# Altium schematic sheet DiPho_AFE.SchDoc
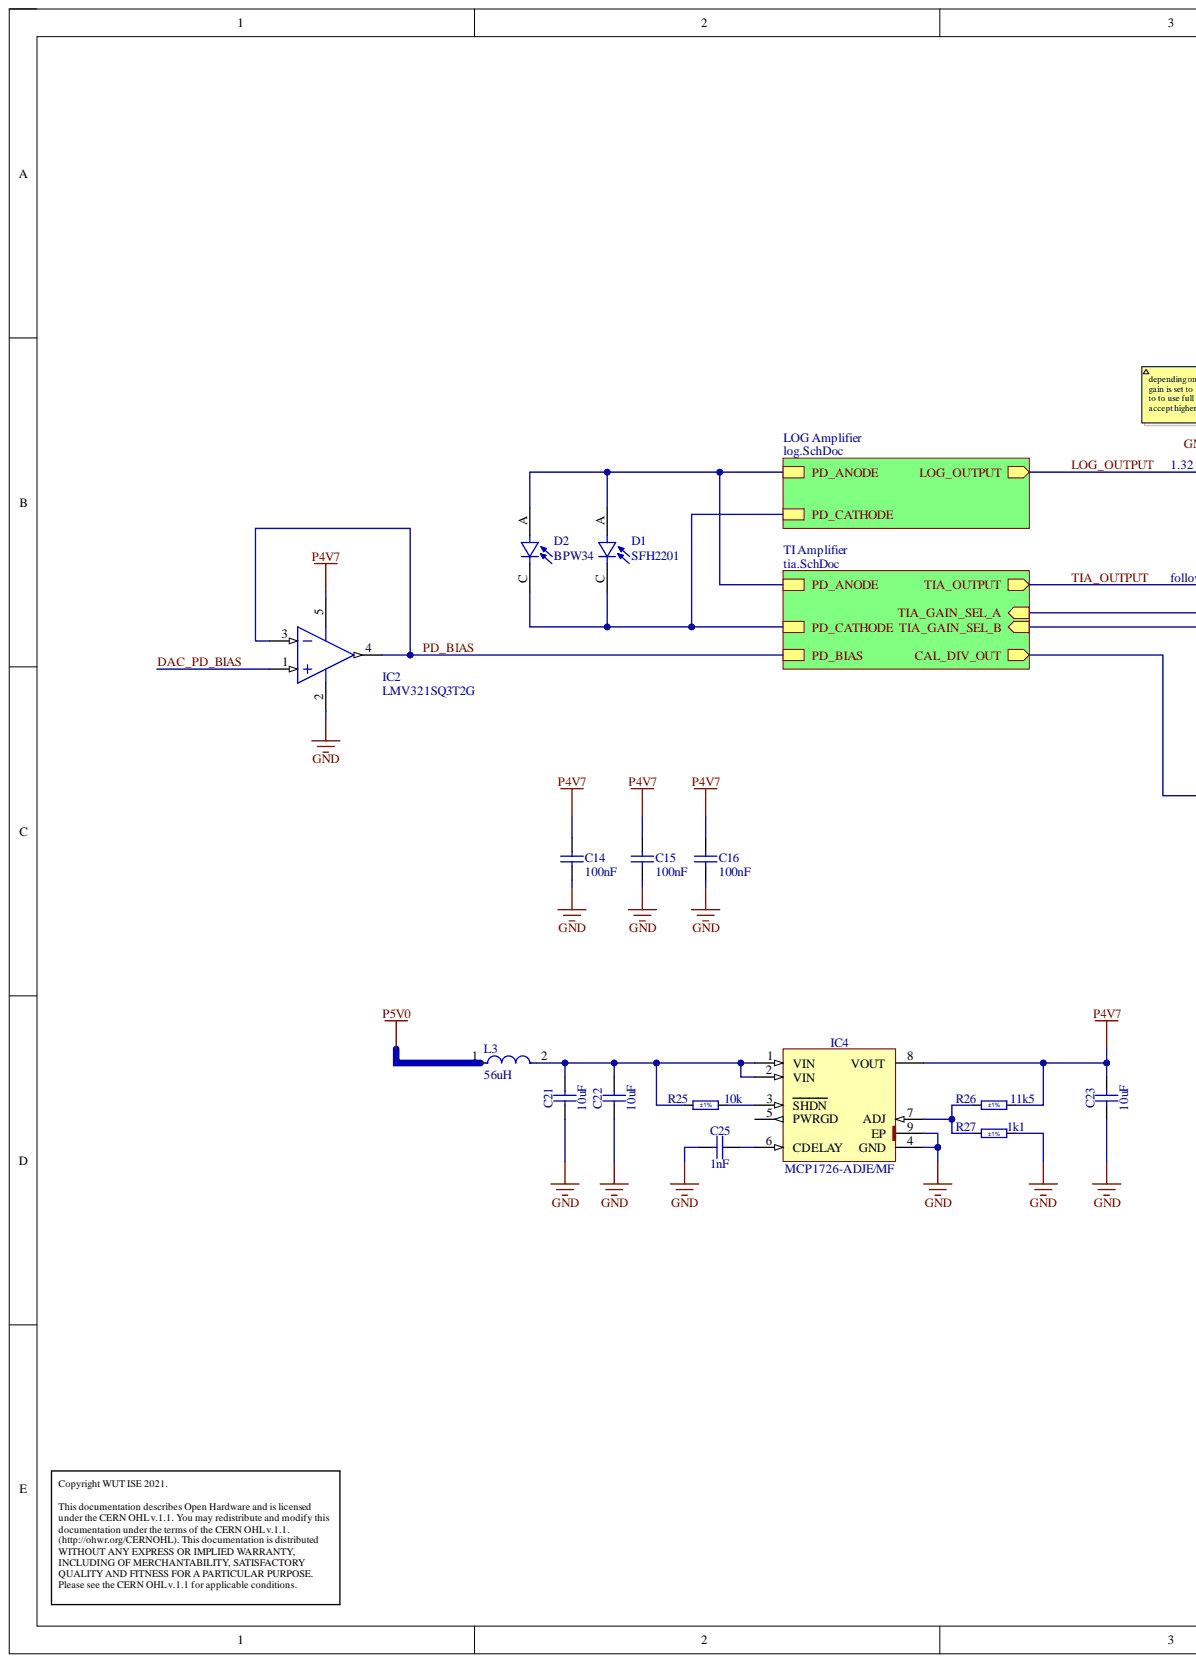
[diagram p0: DiPho_AFE.SchDoc, left]
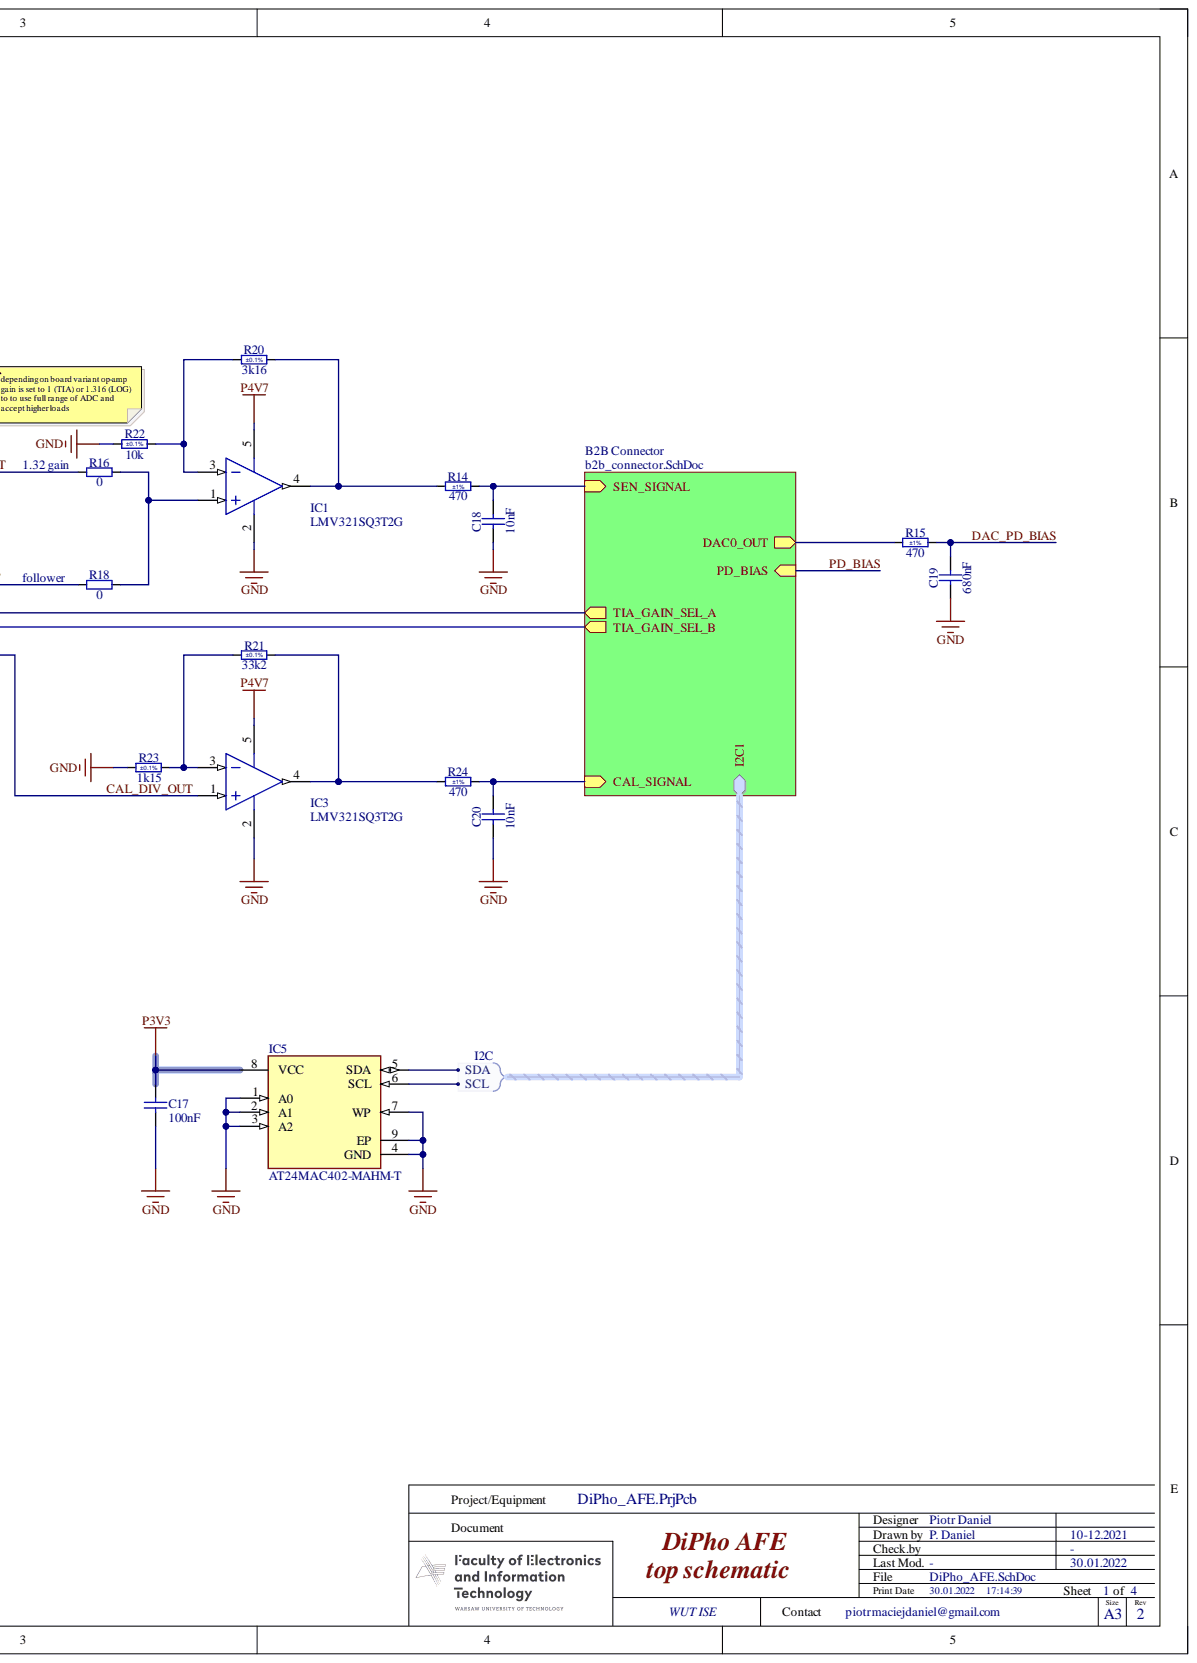
[diagram p1: DiPho_AFE.SchDoc, right]

## Parts
C14  100nF  [CAPC1005X55N]
C15  100nF  [CAPC1005X55N]
C16  100nF  [CAPC1005X55N]
C17  100nF  [CAPC1005X55N]
C18  10nF  [CAPC1005X55N]
C19  680nF  [CAPC1005X55N]
C20  10nF  [CAPC1005X55N]
C21  10uF  [CAPC2012X135N]
C22  10uF  [CAPC2012X135N]
C23  10uF  [CAPC2012X135N]
C25  1nF  [CAPC1005X55N]
D1  SFH2201  [SFH_2201]
D2  BPW34  [BPW34]
IC1  LMV321SQ3T2G  [ONSC-SC70-5-419A-02_K_N]
IC2  LMV321SQ3T2G  [ONSC-SC70-5-419A-02_K_N]
IC3  LMV321SQ3T2G  [ONSC-SC70-5-419A-02_K_N]
IC4  MCP1726-ADJE/MF  [SON65P300X300X100-9N-R160X240]
IC5  AT24MAC402-MAHM-T  [FP-C04-21355-Q4B-MFG]
L3  56uH  [FP-LQH3NPH_ME-MFG]
R14  470  Tolerance: ±1%  [RESC1005X40N]
R15  470  Tolerance: ±1%  [RESC1005X40N]
R16  0  [RESC1005X40N]
R18  0  [RESC1005X40N]
R20  3k16  Tolerance: ±0.1%  [RESC1005X35N]
R21  33k2  Tolerance: ±0.1%  [RESC1005X40N]
R22  10k  Tolerance: ±0.1%  [RESC1005X35N]
R23  1k15  Tolerance: ±0.1%  [RESC1005X35N]
R24  470  Tolerance: ±1%  [RESC1005X40N]
R25  10k  Tolerance: ±1%  [RESC1005X40N]
R26  11k5  Tolerance: ±1%  [RESC1005X40N]
R27  1k1  Tolerance: ±1%  [RESC1005X40N]

## Nets
GND: C14.1 C15.1 C16.1 C17.1 C18.1 C19.1 C20.1 C21.1 C22.1 C23.1 C25.1 IC1.2 IC2.2 IC3.2 IC4.4(GND) IC4.9(EP) IC5.1(A0) IC5.2(A1) IC5.3(A2) IC5.4(GND) IC5.7(WP) IC5.9(EP) R22.1 R23.1 R27.2
P3V3: C17.2 IC5.8(VCC)
P4V7: C14.2 C15.2 C16.2 C23.2 IC1.5 IC2.5 IC3.5 IC4.8(VOUT) R26.2
P5V0: L3.1
CAL_DIV_OUT: IC3.1
DAC_PD_BIAS: C19.2 IC2.1 R15.2
LOG_OUTPUT: R16.1
PD_BIAS: IC2.3 IC2.4
TIA_OUTPUT: R18.1
NetC18_2: C18.2 R14.2
NetC20_2: C20.2 R24.2
NetC21_2: C21.2 C22.2 IC4.1(VIN) IC4.2(VIN) L3.2 R25.1
NetC25_2: C25.2 IC4.6(CDELAY)
NetD1_A: D1.A D2.A
NetD1_C: D1.C D2.C
NetIC1_1: IC1.1 R16.2 R18.2
NetIC1_3: IC1.3 R20.1 R22.2
NetIC1_4: IC1.4 R14.1 R20.2
NetIC3_3: IC3.3 R21.1 R23.2
NetIC3_4: IC3.4 R21.2 R24.1
NetIC4_3: IC4.3(SHDN) R25.2
NetIC4_5: IC4.5(PWRGD)
NetIC4_7: IC4.7(ADJ) R26.1 R27.1
NetIC5_5: IC5.5(SDA)
NetIC5_6: IC5.6(SCL)
NetR15_1: R15.1
TIA_GAIN_SEL_A:
TIA_GAIN_SEL_B:

## Library data of the parts
C14-C17 = CC0402_100NF_16V_10%_X7R: Non-polarised capacitor; Manufacturer: GENERIC; Manufacturer1 Part Number: GRM155R71C104KA88D; Part Description: SMD Multilayer Chip Ceramic Capacitor; Database Table Name: Capacitors SMD; TC: X7R; Tolerance: ±10%; Voltage: 16V; Case: 0402; Component Kind: Standard; Component Type: Standard; CreateDate: 05.12.2013; HelpURL: \\cern.ch\dfs\Applications\Altium\Datasheets\CC0402_X7R_MURATA_GRM.pdf; LatestRevisionDate: 03.12.2007; Library Name: Capacitors.DbLib; Manufacturer1 ComponentHeight: 0.55mm; Manufacturer1 Example: MURATA; Manufacturer Part Number: CC0402_100NF_16V_10%_X7R; Mounted: Yes; Part Number: CC0402_100NF_16V_10%_X7R; Pin Count: 2; Sense: No; SMD: Yes; Socket: No; Status: Not Recommended; Value: 100nF; Author: CERN DEM JLC
C18 C20 = CC0402_10NF_16V_10%_X7R: Non-polarised capacitor; Manufacturer: GENERIC; Manufacturer1 Part Number: 223878715636; Part Description: SMD Multilayer Chip Ceramic Capacitor; Database Table Name: Capacitors SMD; TC: X7R; Tolerance: ±10%; Voltage: 16V; Case: 0402; Component Kind: Standard; Component Type: Standard; CreateDate: 03.12.2007; HelpURL: \\cern.ch\dfs\Applications\Altium\Datasheets\CC0402_X7R_PHYCOMP.pdf; LatestRevisionDate: 03.12.2007; Library Name: Capacitors.DbLib; Manufacturer1 ComponentHeight: 0.55mm; Manufacturer1 Example: PHYCOMP; Manufacturer Part Number: CC0402_10NF_16V_10%_X7R; Mounted: Yes; Part Number: CC0402_10NF_16V_10%_X7R; Pin Count: 2; Sense: No; SMD: Yes; Socket: No; Status: Not Recommended; Value: 10nF; Author: CERN DEM JLC
C19 = CC0402_680NF_10V_10%_X5R: Non-polarised capacitor; Manufacturer: GENERIC; Manufacturer1 Part Number: GRM155R61A684KE15; Part Description: SMD Multilayer Chip Ceramic Capacitor; Database Table Name: Capacitors SMD; TC: X5R; Tolerance: ±10%; Voltage: 10V; Case: 0402; Component Kind: Standard; Component Type: Standard; CreateDate: 19.04.2021; HelpURL: \\cern.ch\dfs\Applications\Altium\Datasheets\CC0402_X5R_MURATA_GRM.pdf; LatestRevisionDate: 19.04.2021; Library Name: Capacitors.DbLib; Manufacturer1 ComponentHeight: 0.55mm; Manufacturer1 Example: MURATA; Manufacturer Part Number: CC0402_680NF_10V_10%_X5R; Mounted: Yes; Part Number: CC0402_680NF_10V_10%_X5R; Pin Count: 2; Sense: No; SMD: Yes; Socket: No; Status: None; Value: 680nF; Author: CERN DEM BC
C21-C23 = CC0805_10UF_10V_10%_X7R: Non-polarised capacitor; Manufacturer: GENERIC; Manufacturer1 Part Number: GRM21BR71A106KE51L; Part Description: SMD Multilayer Chip Ceramic Capacitor; Database Table Name: Capacitors SMD; TC: X7R; Tolerance: ±10%; Voltage: 10V; Case: 0805; Component Kind: Standard; Component Type: Standard; CreateDate: 30.04.2012; HelpURL: \\cern.ch\dfs\Applications\Altium\Datasheets\CC0805_X7R_MURATA.pdf; LatestRevisionDate: 30.04.2012; Library Name: Capacitors.DbLib; Manufacturer1 ComponentHeight: 1.35mm; Manufacturer1 Example: MURATA; Manufacturer Part Number: CC0805_10UF_10V_10%_X7R; Mounted: Yes; Part Number: CC0805_10UF_10V_10%_X7R; Pin Count: 2; Sense: No; SMD: Yes; Socket: No; Status: Preferred; Value: 10uF; Author: CERN DEM JLC
C25 = CC0402_1NF_50V_10%_X7R: Non-polarised capacitor; Manufacturer: GENERIC; Manufacturer1 Part Number: 223858715623; Part Description: SMD Multilayer Chip Ceramic Capacitor; Database Table Name: Capacitors SMD; TC: X7R; Tolerance: ±10%; Voltage: 50V; Case: 0402; Component Kind: Standard; Component Type: Standard; CreateDate: 03.12.2007; HelpURL: \\cern.ch\dfs\Applications\Altium\Datasheets\CC0402_X7R_PHYCOMP.pdf; LatestRevisionDate: 03.12.2007; Library Name: Capacitors.DbLib; Manufacturer1 ComponentHeight: 0.55mm; Manufacturer1 Example: PHYCOMP; Manufacturer Part Number: CC0402_1NF_50V_10%_X7R; Mounted: Yes; Part Number: CC0402_1NF_50V_10%_X7R; Pin Count: 2; Sense: No; SMD: Yes; Socket: No; Status: Not Recommended; Value: 1nF; Author: CERN DEM JLC
D2 = BPW34: VISHAY - BPW34 - Photodiode, 65 °, 2 nA, 900 nm, DIP-2; Breakdown Voltage: 60V; Dark Current: 2nA; Diode Type: PIN; Fall Time: 100ns; Lens Style: Clear; Max Power Dissipation: 215mW; Viewing Angle: 130°; Wavelength: 900nm; Case/Package: DIP; Category: Photodiodes; Component Kind: Standard; ComponentLink1Description: https://datasheet.ciiva.com/1248/33605-1248690.pdf?src-supplier=Element14; ComponentLink1URL: https://datasheet.ciiva.com/1248/33605-1248690.pdf?src-supplier=Element14; ComponentLink2Description: https://datasheet.ciiva.com/1249/bpw34-1249073.pdf?src-supplier=Element14; ComponentLink2URL: https://datasheet.ciiva.com/1249/bpw34-1249073.pdf?src-supplier=Element14; ComponentLink3Description: https://datasheet.ciiva.com/25620/0900766b80de9fc5-25620357.pdf?src-supplier=RSComponents; ComponentLink3URL: https://datasheet.ciiva.com/25620/0900766b80de9fc5-25620357.pdf?src-supplier=RSComponents; ComponentLink4Description: https://datasheet.ciiva.com/4697/bpw34-79369-4697616.pdf?src-supplier=Mouser; ComponentLink4URL: https://datasheet.ciiva.com/4697/bpw34-79369-4697616.pdf?src-supplier=Mouser; ComponentLink5Description: https://datasheet.ciiva.com/1336/6514-1336219.pdf?src-supplier=Newark; ComponentLink5URL: https://datasheet.ciiva.com/1336/6514-1336219.pdf?src-supplier=Newark; ComponentLink6Description: https://datasheet.ciiva.com/4269/2bpw34-4269812.pdf?src-supplier=Arrow+Electronics; ComponentLink6URL: https://datasheet.ciiva.com/4269/2bpw34-4269812.pdf?src-supplier=Arrow+Electronics; ComponentLink7Description: https://datasheet.ciiva.com/15117/getdatasheetpartid-112064-15117125.pdf?src-supplier=Verical; ComponentLink7URL: https://datasheet.ciiva.com/15117/getdatasheetpartid-112064-15117125.pdf?src-supplier=Verical; ComponentLink8Description: https://datasheet.ciiva.com/1248/bpw34-1248686.pdf?src-supplier=Element14; ComponentLink8URL: https://datasheet.ciiva.com/1248/bpw34-1248686.pdf?src-supplier=Element14; ComponentLink9Description: https://datasheet.ciiva.com/1335/1809684-1335889.pdf?src-supplier=Newark; ComponentLink9URL: https://datasheet.ciiva.com/1335/1809684-1335889.pdf?src-supplier=Newark; ComponentLink10Description: https://datasheet.ciiva.com/2819/bpw34-2819744.pdf?src-supplier=Digi-Key; ComponentLink10URL: https://datasheet.ciiva.com/2819/bpw34-2819744.pdf?src-supplier=Digi-Key; ComponentLink11Description: https://datasheet.ciiva.com/8084/bpw34-8084840.pdf?src-supplier=Avnet; ComponentLink11URL: https://datasheet.ciiva.com/8084/bpw34-8084840.pdf?src-supplier=Avnet; Contact Plating: Tin; Height: 2mm; Lead Free: Lead Free; Length: 4.5mm; Library Name: DiPho.SchLib; Manufacturer: Vishay; Manufacturer Part Number: BPW34; Max Operating Temperature: 100°C; Max Reverse Voltage (DC): 60V; Min Operating Temperature: -40°C; Mount: Through Hole,PCB; Number of Pins: 2; Package Quantity: 3000; Packaging: Bulk; Power Dissipation: 215mW; Pricing 1: 1=1,06000, 10=0,94400, 100=0,73630, 500=0,60822, 1000=0,48018, 2000=0,45300 (USD); Radiation Hardening: No; REACH SVHC: Unknown; Reverse Breakdown Voltage: 60V; Reverse Voltage (DC): 60V; Rise Time: 100ns; RoHS: Yes; Stock 1: 56; Supplier 1: Digi-Key; Supplier Part Number 1: 751-1015-ND; Width: 4.3mm
IC1-IC3 = CMP-1310-00136-1: Single Low-Voltage, Rail-to-Rail Operational Amplifier, 2.7 to 5.5 V, -40 to 85 degC, 5-Pin SC70, Pb-Free, Tape and Reel; Manufacturer: On Semiconductor; GBW Typ (MHz): 1; VCC Max (V): 5.5; VCC Min (V): 2.7; Component Kind: Standard; ComponentLink1Description: Manufacturer URL; ComponentLink1URL: http://www.onsemi.com/; ComponentLink2Description: Datasheet; ComponentLink2URL: http://www.onsemi.cn/pub_link/Collateral/LMV321-LMV358-LMV324-D.PDF; ComponentLink3Description: Package Specification; ComponentLink3URL: http://www.onsemi.cn/pub_link/Collateral/LMV821-D.PDF; DatasheetVersion: Rev. 10; Library Name: Altium Content Vault; Mounting Technology: Surface Mount; PackageDescription: 5-Pin Small Outline Transistor, Body 2 x 1.25 mm, Pitch 0.65 mm; PackageReference: SC70-5-419A-02_K; PackageVersion: Rev. K, 07/2010; Packing: Tape and Reel; PartNumber: LMV321SQ3T2G; RoHS: TRUE; SR Typ (V/uS): 1
IC4 = MCP1726-ADJE/MF: 1A, 0.8V to 5.0V Adjustable , Low Quiescent Current LDO Regulator; Manufacturer: MICROCHIP TECHNOLOGY; Bonding: No; Database Table Name: Regulators; PressFit: No; Case: SON8; Component Kind: Standard; Component Type: Standard; ComponentHeight: 1mm; CreateDate: 07.02.2012; Device: MCP1726-ADJE/MF; HelpURL: \\cern.ch\dfs\Applications\Altium\Datasheets\MCP1726-ADJE-MF.pdf; LatestRevisionDate: 07.02.2012; Library Name: ICs And Semiconductors.DbLib; Manufacturer Part Number: MCP1726-ADJE/MF; Mounted: Yes; PackageDescription: SON, 0.65mm pitch, 8 pin, 3mm X 3mm X 1.00mm H body (w/thermal tab 1.60 X 2.4mm),  IPC Medium Density; Part Description: 1A, 0.8V to 5.0V Adjustable, Low Quiescent Current LDO Regulator; Part Number: MCP1726-ADJE/MF; Pin Count: 8; Sense: No; SMD: Yes; Socket: No; Author: CERN DEM JLC
IC5 = CMP-06313-000089-1: IC EEPROM 2K I2C 1MHZ 8UDFN; Access Time: 550ns; Memory Size: 2Kb; Memory Type: Non-Volatile; Component Kind: Standard; Frequency: 1MHz; Library Name: Altium Content Vault; Max Operating Temperature: 85°C; Min Operating Temperature: -40°C; Mount: Surface Mount; Packaging: Cut Tape; RoHS Compliant: Yes
L3 = CMP-06042-011728-1: Wire Wound Ferrite Inductor for Power Lines 56μH ±20% 1.2Ω 500mA 1212; Component Kind: Standard; Library Name: Altium Content Vault
R14 R15 R24 = R0402_470R_1%_0.0625W_100PPM: Resistor - 1%; Manufacturer: GENERIC; Manufacturer1 Part Number: 232270674701L; Part Description: General Purpose Thick Film Chip Resistor; Database Table Name: Resistors SMD; PressFit: No; TC: ±100ppm/°C; Case: 0402; Component Kind: Standard; Component Type: Standard; CreateDate: 03.12.2007; HelpURL: \\cern.ch\dfs\Applications\Altium\Datasheets\R0402_Phycomp_RC0402.pdf; LatestRevisionDate: 17.10.2012; Library Name: Resistors.DbLib; Manufacturer1 ComponentHeight: 0.4mm; Manufacturer1 Example: YAGEO PHYCOMP; Manufacturer Part Number: R0402_470R_1%_0.0625W_100PPM; Mounted: Yes; Part Number: R0402_470R_1%_0.0625W_100PPM; Pin Count: 2; Power: 0.0625W; Sense: No; SMD: Yes; Socket: No; Status: Not Recommended; Value: 470; Author: CERN DEM JLC
R16 R18 = R0402_0R_JUMPER: Resistor; Manufacturer: GENERIC; Manufacturer1 Part Number: 232270591001L; Part Description: 1A (0.05R Max DC Resistance) Zero Ohm Jumper; Database Table Name: Resistors SMD; PressFit: No; Case: 0402; Component Kind: Standard; Component Type: Standard; CreateDate: 03.12.2007; HelpURL: \\cern.ch\dfs\Applications\Altium\Datasheets\R0402_Phycomp_RC0402.pdf; LatestRevisionDate: 17.10.2012; Library Name: Resistors.DbLib; Manufacturer1 ComponentHeight: 0.4mm; Manufacturer1 Example: YAGEO PHYCOMP; Manufacturer Part Number: R0402_0R_JUMPER; Mounted: Yes; Part Number: R0402_0R_JUMPER; Pin Count: 2; Sense: No; SMD: Yes; Socket: No; Status: Not Recommended; Value: 0; Author: CERN DEM JLC
R20 R23 = R0402_10K_0.1%_0.063W_25PPM: Resistor - 0.1%; Manufacturer: GENERIC; Manufacturer1 Part Number: CPF0402B10KE1; Part Description: Thin Film Precision Resistor; Database Table Name: Resistors SMD; Power: 0.063W; PressFit: No; TC: ±25ppm/°C; Value: 10k; Case: 0402; Component Kind: Standard; Component Type: Standard; CreateDate: 22.09.2015; HelpURL: \\cern.ch\dfs\Applications\Altium\Datasheets\R0402_TYCO_CPF.pdf; LatestRevisionDate: 22.09.2015; Library Name: Resistors.DbLib; Manufacturer1 ComponentHeight: 0.35mm; Manufacturer1 Example: TE CONNECTIVITY; Manufacturer Part Number: R0402_10K_0.1%_0.063W_25PPM; Mounted: Yes; Part Number: R0402_10K_0.1%_0.063W_25PPM; Pin Count: 2; Sense: No; SMD: Yes; Socket: No; Status: Not Recommended; Author: CERN DEM JMW
R21 = R0402_33K2_0.1%_0.063W_25PPM: Resistor - 0.1%; Manufacturer: GENERIC; Manufacturer1 Part Number: ERA2AEB3322X; Part Description: Thin Film Precision Resistor; Database Table Name: Resistors SMD; Power: 0.063W; PressFit: No; TC: ±25ppm/°C; Voltage: 50V; Case: 0402; Component Kind: Standard; Component Type: Standard; CreateDate: 09.07.2020; HelpURL: \\cern.ch\dfs\Applications\Altium\Datasheets\R0402_PANASONIC_ERA.pdf; LatestRevisionDate: 09.07.2020; Library Name: Resistors.DbLib; Manufacturer1 ComponentHeight: 0.4mm; Manufacturer1 Example: PANASONIC; Manufacturer Part Number: R0402_33K2_0.1%_0.063W_25PPM; Mounted: Yes; Part Number: R0402_33K2_0.1%_0.063W_25PPM; Pin Count: 2; Sense: No; SMD: Yes; Socket: No; Status: Not Recommended; Value: 33k2; Author: CERN DEM BC
R22 = R0402_10K_0.1%_0.063W_25PPM: Resistor - 0.1%; Manufacturer: GENERIC; Manufacturer1 Part Number: CPF0402B10KE1; Part Description: Thin Film Precision Resistor; Database Table Name: Resistors SMD; Power: 0.063W; PressFit: No; TC: ±25ppm/°C; Case: 0402; Component Kind: Standard; Component Type: Standard; CreateDate: 22.09.2015; HelpURL: \\cern.ch\dfs\Applications\Altium\Datasheets\R0402_TYCO_CPF.pdf; LatestRevisionDate: 22.09.2015; Library Name: Resistors.DbLib; Manufacturer1 ComponentHeight: 0.35mm; Manufacturer1 Example: TE CONNECTIVITY; Manufacturer Part Number: R0402_10K_0.1%_0.063W_25PPM; Mounted: Yes; Part Number: R0402_10K_0.1%_0.063W_25PPM; Pin Count: 2; Sense: No; SMD: Yes; Socket: No; Status: Not Recommended; Value: 10k; Author: CERN DEM JMW
R25 = R0402_10K_1%_0.0625W_100PPM: Resistor - 1%; Manufacturer: GENERIC; Manufacturer1 Part Number: 232270671003L; Part Description: General Purpose Thick Film Chip Resistor; Database Table Name: Resistors SMD; PressFit: No; TC: ±100ppm/°C; Case: 0402; Component Kind: Standard; Component Type: Standard; CreateDate: 03.12.2007; HelpURL: \\cern.ch\dfs\Applications\Altium\Datasheets\R0402_Phycomp_RC0402.pdf; LatestRevisionDate: 17.10.2012; Library Name: Resistors.DbLib; Manufacturer1 ComponentHeight: 0.4mm; Manufacturer1 Example: YAGEO PHYCOMP; Manufacturer Part Number: R0402_10K_1%_0.0625W_100PPM; Mounted: Yes; Part Number: R0402_10K_1%_0.0625W_100PPM; Pin Count: 2; Power: 0.0625W; Sense: No; SMD: Yes; Socket: No; Status: Not Recommended; Value: 10k; Author: CERN DEM JLC
R26 = R0402_11K5_1%_0.063W_100PPM: Resistor - 1%; Manufacturer: GENERIC; Manufacturer1 Part Number: CRCW040211K5FK; Part Description: Standard Thick Film Chip Resistor; Database Table Name: Resistors SMD; Power: 0.063W; PressFit: No; TC: ±100ppm/°C; Case: 0402; Component Kind: Standard; Component Type: Standard; CreateDate: 02.06.2020; HelpURL: \\cern.ch\dfs\Applications\Altium\Datasheets\R0402_VISHAY_CRCW e3.pdf; LatestRevisionDate: 02.06.2020; Library Name: Resistors.DbLib; Manufacturer1 ComponentHeight: 0.4mm; Manufacturer1 Example: VISHAY; Manufacturer Part Number: R0402_11K5_1%_0.063W_100PPM; Mounted: Yes; Part Number: R0402_11K5_1%_0.063W_100PPM; Pin Count: 2; Sense: No; SMD: Yes; Socket: No; Status: None; Value: 11k5; Author: CERN DEM BC
R27 = R0402_1K1_1%_0.0625W_100PPM: Resistor - 1%; Manufacturer: GENERIC; Manufacturer1 Part Number: 232270671102L; Part Description: General Purpose Thick Film Chip Resistor; Database Table Name: Resistors SMD; PressFit: No; TC: ±100ppm/°C; Case: 0402; Component Kind: Standard; Component Type: Standard; CreateDate: 03.12.2007; HelpURL: \\cern.ch\dfs\Applications\Altium\Datasheets\R0402_Phycomp_RC0402.pdf; LatestRevisionDate: 17.10.2012; Library Name: Resistors.DbLib; Manufacturer1 ComponentHeight: 0.4mm; Manufacturer1 Example: YAGEO PHYCOMP; Manufacturer Part Number: R0402_1K1_1%_0.0625W_100PPM; Mounted: Yes; Part Number: R0402_1K1_1%_0.0625W_100PPM; Pin Count: 2; Power: 0.0625W; Sense: No; SMD: Yes; Socket: No; Status: Not Recommended; Value: 1k1; Author: CERN DEM JLC
D1 = SFH2201: Component Kind: Standard; Library Name: DiPho.SchLib
